# Altium schematic sheet Power.SchDoc(Power)
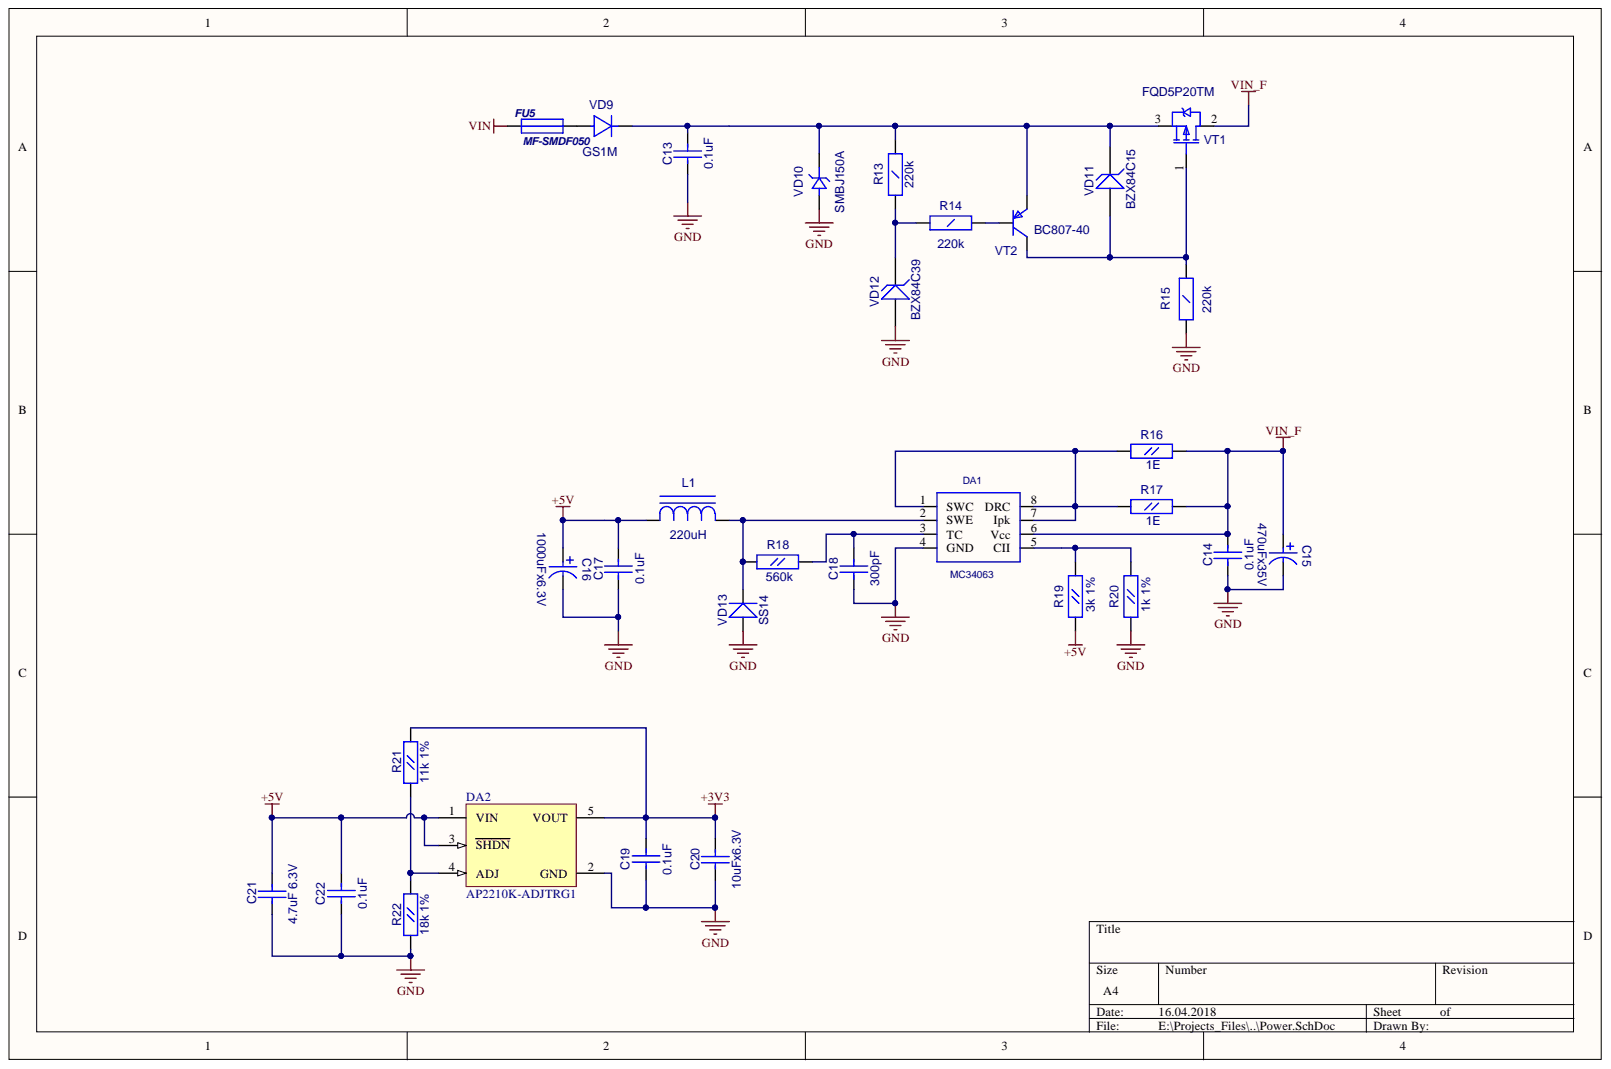
[diagram p0: Power.SchDoc]

## Parts
C13  0.1uF  [CC1206]
C14  0.1uF  [CC0603]
C15  470uFx35V  [CC16X10_CAP0.5(1)(Primary)]
C16  1000uFx6.3V  [CC8X3.5]
C17  0.1uF  [CC0603]
C18  300pF  [CC0603]
C19  0.1uF  [CC0603]
C20  10uFx6.3V  [CC0805]
C21  4.7uF 6.3V  [CC0805]
C22  0.1uF  [CC0603]
DA1  MC34063  [SO-8]
DA2  AP2210K-ADJTRG1  [SOT23-5]
FU5  MF-SMDF050  [MF-SMDF]
L1  220uH  [SDR0805]
R13  220k  [RC1206]
R14  220k  [RC1206]
R15  220k  [RC1206]
R16  1E  [RC0805]
R17  1E  [RC0805]
R18  560k  [RC0603]
R19  3k 1%  [RC0603]
R20  1k 1%  [RC0603]
R21  11k 1%  [RC0603]
R22  18k 1%  [RC0603]
VD9  GS1M  [SMA]
VD10  SMBJ150A  [SMB]
VD11  BZX84C15  [SOT-23(123)]
VD12  BZX84C39  [SOT-23(123)]
VD13  SS14  [SMA]
VT1  FQD5P20TM  [D-PAK(TO-252AA)]
VT2  BC807-40  [SOT-23(123)]

## Nets
+3V3: C19.1 C20.2 DA2.5(VOUT) R21.2
+5V: C16.1 C17.2 C21.2 C22.1 DA2.1(VIN) DA2.3(SHDN) L1.1 R19.1
GND: C13.1 C14.1 C15.1 C16.2 C17.1 C18.1 C19.2 C20.1 C21.1 C22.2 DA1.4(GND) DA2.2(GND) R15.2 R20.1 R22.1 VD10.1 VD12.1 VD13.1
VIN: FU5.1
VIN_F: C14.2 C15.2 DA1.6(Vcc) R16.2 R17.2 VT1.2
NetC13_2: C13.2 R13.2 VD9.2 VD10.2 VD11.3 VT1.3 VT2.2
NetC18_2: C18.2 DA1.3(TC) R18.2
NetDA1_1: DA1.1(SWC) DA1.7(Ipk) DA1.8(DRC) R16.1 R17.1
NetDA1_2: DA1.2(SWE) L1.2 R18.1 VD13.2
NetDA1_5: DA1.5(CII) R19.2 R20.2
NetDA2_4: DA2.4(ADJ) R21.1 R22.2
NetFU5_2: FU5.2 VD9.1
NetR13_1: R13.1 R14.1 VD12.3
NetR14_2: R14.2 VT2.1
NetR15_1: R15.1 VD11.1 VT1.1 VT2.3

## Library data of the parts
C13 = CC1206: Value: 0.1uF; Description: CC1206; Component Kind: Standard; Library Name: gprs_modem_2.SchLib
C14 C17-C19 C22 = CC0603: Description: CC0603; Component Kind: Standard; Library Name: gprs_modem_2.SchLib
  C14 C17 C19 C22: Value: 0.1uF
  C18: Value: 300pF
C15 = CAP0.5(1): Value: 470uFx35V; Description: CAP0.5(1); Component Kind: Standard; Library Name: gprs_modem_2.SchLib
C16 = CAP0.35: Value: 1000uFx6.3V; Description: CAP0.35; Component Kind: Standard; Library Name: gprs_modem_2.SchLib
C20 C21 = CC0805: Description: CC0805; Component Kind: Standard; Library Name: gprs_modem_2.SchLib
  C20: Value: 10uFx6.3V
  C21: Value: 4.7uF 6.3V
DA2 = MCP1824T-ADJE/OT: 300 mA, Low Voltage, Low Quiescent Current LDO Regulator, 5-Pin SOT-223, Extended Temperature, Tape and Reel; Published: 29-Jan-2009; PackageVersion: revAW, Oct-2008; PackageReference: SOT-223-DC5; PackageDescription: 5-Lead Plastic Small Outline Transistor (DC) [SOT-223]; DatasheetVersion: revA, Aug-2007; ComponentLink3URL: http://ww1.microchip.com/downloads/en/PackagingSpec/00049AW.pdf; ComponentLink3Description: Packaging Specification; ComponentLink2URL: http://ww1.microchip.com/downloads/en/DeviceDoc/22070a.pdf; ComponentLink2Description: Datasheet; ComponentLink1URL: http://www.microchip.com; ComponentLink1Description: Manufacturer Link; Component Kind: Standard; Publisher: Altium Limited; Library Name: gprs_modem_2.SchLib
FU5 = MF-SMDF: Value: MF-SMDF050; Description: MF-SMDF; Component Kind: Standard; Library Name: gprs_modem_2.SchLib
L1 = SDR0805: Value: 220uH; Description: SDR0805; Component Kind: Standard; Library Name: gprs_modem_2.SchLib
R13-R15 = RC1206: Value: 220k; Description: RC1206; Component Kind: Standard; Library Name: gprs_modem_2.SchLib
R16 R17 = RC0805: Value: 1E; Description: RC0805; Component Kind: Standard; Library Name: gprs_modem_2.SchLib
R18-R22 = RC0603: Description: RC0603; Component Kind: Standard; Library Name: gprs_modem_2.SchLib
  R18: Value: 560k
  R19: Value: 3k 1%
  R20: Value: 1k 1%
  R21: Value: 11k 1%
  R22: Value: 18k 1%
VD9 = GS1M: Value: {Value}; Description: GS1M; Component Kind: Standard; Library Name: gprs_modem.SchLib
VD10 = SMBJ: Value: SMBJ150A; Description: SMBJ; Component Kind: Standard; Library Name: gprs_modem_2.SchLib
VD11 VD12 = BZX84: Zener Voltage Regulator Diode; Value: {Value}; Component Kind: Standard; Library Name: gprs_modem_2.SchLib
VD13 = SS14: Schottky Rectifier; OriginalName: 10BQ100; ComponentField6: Published 8-Jun-2000, Modified 10-July-2000: SIM Model Added.; Value: SS14; Simulation: 10BQ100; Component Kind: Standard; Library Name: gprs_modem_2.SchLib
VT1 = IRFR9220: HEXFET Power MOSFET; OriginalName: IRLML5103; ComponentField7: Published 8-Jun-2000; Value: FQD5P20TM; Component Kind: Standard; Library Name: gprs_modem_2.SchLib
VT2 = BC857B: OriginalName: BC846BLT1; ComponentField7: Published 29-Sep-2000; Value: BC807-40; Simulation: BC846BLT1; Description: BC857B; Component Kind: Standard; Library Name: gprs_modem.SchLib
DA1 = MC34063: Description: MC34063; Component Kind: Standard; Library Name: Schetchik.SchLib
